# Altium schematic sheet [02] - nRF52.SchDoc("[02] - nRF52")
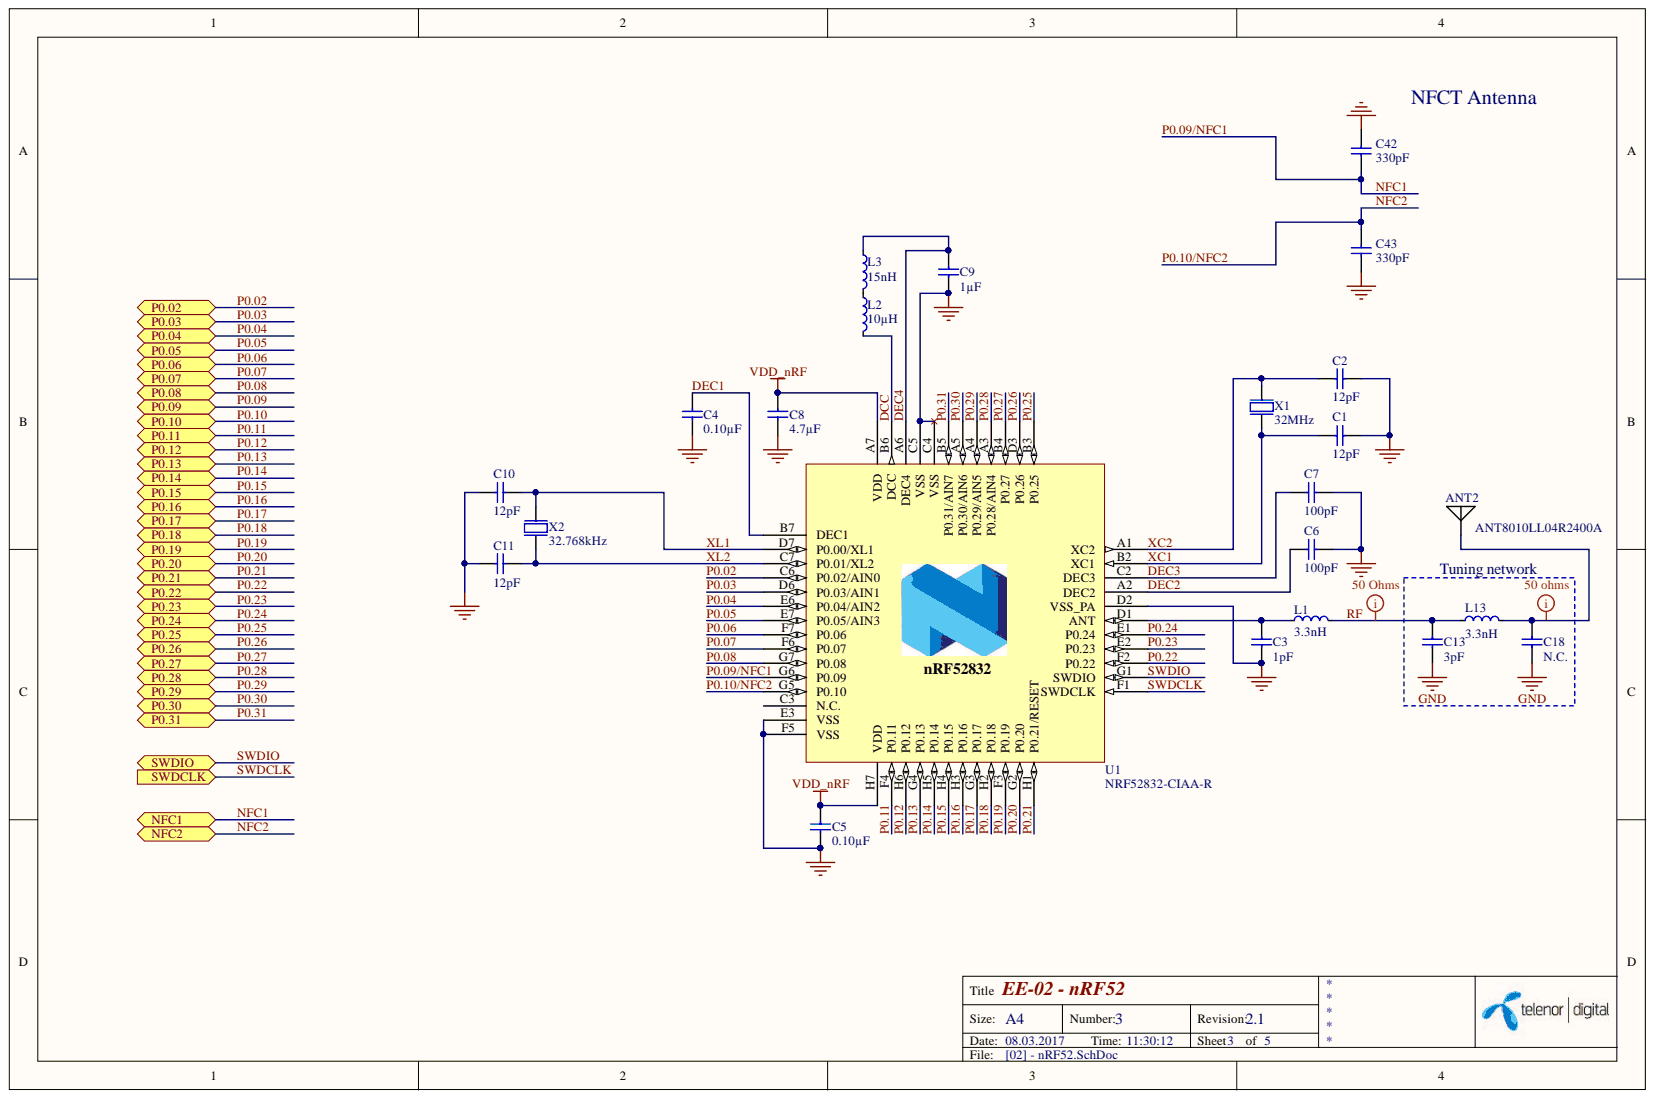
[diagram p0: [02] - nRF52.SchDoc]

## Parts
ANT2  ANT8010LL04R2400A  [YAGEO_24GHz]
C1  12pF  [CAPC0603X03L]
C2  12pF  [CAPC0603X03L]
C3  1pF  [CAPC0603X03L]
C4  0.10µF  [CAPC0603X03L]
C5  0.10µF  [CAPC0603X03L]
C6  100pF  [CAPC0603X03L]
C7  100pF  [CAPC0603X03L]
C8  4.7µF  [CAPC1608X06L]
C9  1µF  [CAPC1005X04L]
C10  12pF  [CAPC0603X03L]
C11  12pF  [CAPC0603X03L]
C13  3pF  [CAPC0603X03L]
C18  N.C.  [CAPC0603X03L]  (no BOM)
C42  330pF  [CAPC0603X03L]
C43  330pF  [CAPC0603X03L]
L1  3.3nH  [INDC0603X03L]
L2  10µH  [INDC1608X06L]
L3  15nH  [INDC1005X04L]
L13  3.3nH  [INDC0603X03L]
U1  NRF52832-CIAA-R  [BGA50C40P7X8_295X322X50]
X1  32MHz  [XTAL_ECS_2016]
X2  32.768kHz  [XTAL_1610]

## Ports
NFC1, NFC2, P0.02, P0.03, P0.04, P0.05, P0.06, P0.07, P0.08, P0.09, P0.10, P0.11, P0.12, P0.13, P0.14, P0.15, P0.16, P0.17, P0.18, P0.19, P0.20, P0.21, P0.22, P0.23, P0.24, P0.25, P0.26, P0.27, P0.28, P0.29, P0.30, P0.31, SWDCLK, SWDIO

## Nets
GND: C1.2 C2.2 C3.1 C4.2 C5.2 C6.2 C7.2 C8.2 C9.1 C10.2 C11.2 C13.1 C18.1 C42.2 C43.1 U1.C4(VSS) U1.C5(VSS) U1.D2(VSS_PA) U1.E3(VSS) U1.F5(VSS) X1.2 X1.4
VDD_nRF: C5.1 C8.1 U1.A7(VDD) U1.H7(VDD)
DCC: L2.2 U1.B6(DCC)
DEC1: C4.1 U1.B7(DEC1)
DEC2: C6.1 U1.A2(DEC2)
DEC3: C7.1 U1.C2(DEC3)
DEC4: C9.2 L3.1 U1.A6(DEC4)
NFC1: C42.1 U1.G6(P0.09) ; port NFC1
NFC2: C43.2 U1.G5(P0.10) ; port NFC2
P0.02: U1.C6(P0.02/AIN0) ; port P0.02
P0.03: U1.D6(P0.03/AIN1) ; port P0.03
P0.04: U1.E6(P0.04/AIN2) ; port P0.04
P0.05: U1.E7(P0.05/AIN3) ; port P0.05
P0.06: U1.F7(P0.06) ; port P0.06
P0.07: U1.F6(P0.07) ; port P0.07
P0.08: U1.G7(P0.08) ; port P0.08
P0.11: U1.F4(P0.11) ; port P0.11
P0.12: U1.H6(P0.12) ; port P0.12
P0.13: U1.G4(P0.13) ; port P0.13
P0.14: U1.H5(P0.14) ; port P0.14
P0.15: U1.H4(P0.15) ; port P0.15
P0.16: U1.H3(P0.16) ; port P0.16
P0.17: U1.G3(P0.17) ; port P0.17
P0.18: U1.H2(P0.18) ; port P0.18
P0.19: U1.F3(P0.19) ; port P0.19
P0.20: U1.G2(P0.20) ; port P0.20
P0.21: U1.H1(P0.21/RESET) ; port P0.21
P0.22: U1.F2(P0.22) ; port P0.22
P0.23: U1.E2(P0.23) ; port P0.23
P0.24: U1.E1(P0.24) ; port P0.24
P0.25: U1.B3(P0.25) ; port P0.25
P0.26: U1.D3(P0.26) ; port P0.26
P0.27: U1.B4(P0.27) ; port P0.27
P0.28: U1.A3(P0.28/AIN4) ; port P0.28
P0.29: U1.A4(P0.29/AIN5) ; port P0.29
P0.30: U1.A5(P0.30/AIN6) ; port P0.30
P0.31: U1.B5(P0.31/AIN7) ; port P0.31
RF: C13.2 L1.2 L13.1
SWDCLK: U1.F1(SWDCLK) ; port SWDCLK
SWDIO: U1.G1(SWDIO) ; port SWDIO
XC1: C1.1 U1.B2(XC1) X1.1
XC2: C2.1 U1.A1(XC2) X1.3
XL1: C10.1 U1.D7(P0.00/XL1) X2.1
XL2: C11.1 U1.C7(P0.01/XL2) X2.2
NetANT2_1: ANT2.1 C18.2 L13.2
NetC3_2: C3.2 L1.1 U1.D1(ANT)
NetL2_1: L2.1 L3.2
P0.09: ; port P0.09
P0.10: ; port P0.10

Not connected: U1 C3(N.C.)

## Library data of the parts
ANT2 = ANT8010LL04R2400A: CHIP ANTENNA 2.4GHZ; Manufacturer: Yageo; Power - Max: 1W; Number of Bands: 1; Gain: 5.46dBi; Frequency Range: 2.4GHz ~ 2.5GHz; Frequency Group: UHF (2 GHz ~ 3 GHz); VSWR: -; Termination: Solder; Supplier Part Number 1: 311-1550-6-ND; Supplier 1: Digi-Key; Stock 1: 771; RoHS: RoHS Compliant; Return Loss: -; Pricing 1: 1=1.4, 10=1.241, 25=1.1204, 100=0.9803, 250=0.85972, 500=0.76248, 750=0.66521, 1000=0.60297 (EUR); Mounting Type: Surface Mount; Manufacturer Part Number: ANT8010LL04R2400A; Ingress Protection: -; Height (Max): 0.039" (1.00mm); Frequency (Center/Band): 2.4GHz; Features: -; ComponentLink1URL: http://www.yageo.com/documents/recent/An_SMD_Bt_11bg_2450_8010_0.pdf; ComponentLink1Description: http://www.yageo.com/documents/recent/An_SMD_Bt_11bg_2450_8010_0.pdf; Component Kind: Standard; Category: RF/IF and RFID; Applications: Bluetooth, WLAN, Zigbee™; Antenna Type: Chip; Library Name: Skunkworks Library.IntLib
C1 C2 C10 C11 = GRM0335C1H120GA01D: CAP CER 12PF 50V NP0 0201; Manufacturer: Murata Electronics North America; Tolerance: ±2%; Temperature Coefficient: C0G, NP0; Voltage - Rated: 50V; Value: 12pF; Thickness (Max): 0.013" (0.33mm); Supplier Part Number 1: 490-11308-6-ND; Supplier 1: Digi-Key; Stock 1: 92426; Size / Dimension: 0.024" L x 0.012" W (0.60mm x 0.30mm); RoHS: RoHS Compliant; Ratings: -; Pricing 1: 1=0.1, 10=0.014, 50=0.0078, 100=0.0066, 250=0.0054, 500=0.00462, 1000=0.0036, 5000=0.003 (USD); Part Status: Active; Packaging: Digi-Reel®; Package / Case: 0201 (0603 Metric); Operating Temperature: -55°C ~ 125°C; Mounting Type: Surface Mount, MLCC; Manufacturer Part Number: GRM0335C1H120GA01D; Lead Style: -; Lead Spacing: -; Height - Seated (Max): -; Features: -; ComponentLink1URL: http://www.murata.com/~/media/webrenewal/support/library/catalog/products/capacitor/mlcc/c02e.pdf; ComponentLink1Description: http://www.murata.com/~/media/webrenewal/support/library/catalog/products/capacitor/mlcc/c02e.pdf; Component Kind: Standard; Category: Capacitors; Capacitance: 12pF; Applications: General Purpose; Library Name: Skunkworks Library.IntLib
C3 = GRM0335C1H1R0BA01D: CAP CER 1PF 50V NP0 0201; Manufacturer: Murata Electronics North America; Tolerance: ±0.1pF; Temperature Coefficient: C0G, NP0; Voltage - Rated: 50V; Value: 1pF; Thickness (Max): 0.013" (0.33mm); Supplier Part Number 1: 490-9660-6-ND; Supplier 1: Digi-Key; Stock 1: 205704; Size / Dimension: 0.024" L x 0.012" W (0.60mm x 0.30mm); RoHS: RoHS Compliant; Ratings: -; Pricing 1: 1=0.1, 10=0.014, 50=0.0078, 100=0.0066, 250=0.0054, 500=0.00462, 1000=0.0036, 5000=0.003 (USD); Part Status: Active; Packaging: Digi-Reel®; Package / Case: 0201 (0603 Metric); Operating Temperature: -55°C ~ 125°C; Mounting Type: Surface Mount, MLCC; Manufacturer Part Number: GRM0335C1H1R0BA01D; Lead Style: -; Lead Spacing: -; Height - Seated (Max): -; Features: -; ComponentLink1URL: http://www.murata.com/~/media/webrenewal/support/library/catalog/products/capacitor/mlcc/c02e.pdf; ComponentLink1Description: http://www.murata.com/~/media/webrenewal/support/library/catalog/products/capacitor/mlcc/c02e.pdf; Component Kind: Standard; Category: Capacitors; Capacitance: 1pF; Applications: General Purpose; Library Name: Skunkworks Library.IntLib
C4 C5 = GRM033R61C104KE84D: CAP CER 0.1UF 16V X5R 0201; Manufacturer: Murata Electronics North America; Tolerance: ±10%; Voltage - Rated: 16V; Value: 0.10µF; Thickness (Max): 0.013" (0.33mm); Temperature Coefficient: X5R; Supplier Part Number 1: 490-9985-6-ND; Supplier 1: Digi-Key; Stock 1: 0; Size / Dimension: 0.024" L x 0.012" W (0.60mm x 0.30mm); RoHS: RoHS Compliant; Ratings: -; Pricing 1: 1=0.1, 10=0.025, 50=0.0136, 100=0.0116, 250=0.00944, 500=0.00808, 1000=0.0063, 5000=0.00525 (USD); Part Status: Active; Packaging: Digi-Reel®; Package / Case: 0201 (0603 Metric); Operating Temperature: -55°C ~ 85°C; Mounting Type: Surface Mount, MLCC; Manufacturer Part Number: GRM033R61C104KE84D; Lead Style: -; Lead Spacing: -; Height - Seated (Max): -; Features: Derating Recommended; ComponentLink1URL: http://www.murata.com/~/media/webrenewal/support/library/catalog/products/capacitor/mlcc/c02e.pdf; ComponentLink1Description: http://www.murata.com/~/media/webrenewal/support/library/catalog/products/capacitor/mlcc/c02e.pdf; Component Kind: Standard; Category: Capacitors; Capacitance: 0.10µF; Applications: General Purpose; Library Name: Skunkworks Library.IntLib
C6 C7 = GRM0335C1H101GA01D: CAP CER 100PF 50V NP0 0201; Manufacturer: Murata Electronics North America; Tolerance: ±2%; Temperature Coefficient: C0G, NP0; Voltage - Rated: 50V; Value: 100pF; Thickness (Max): 0.013" (0.33mm); Supplier Part Number 1: 490-7751-6-ND; Supplier 1: Digi-Key; Stock 1: 457199; Size / Dimension: 0.024" L x 0.012" W (0.60mm x 0.30mm); RoHS: RoHS Compliant; Ratings: -; Pricing 1: 1=0.1, 10=0.014, 50=0.0078, 100=0.0066, 250=0.0054, 500=0.00462, 1000=0.0036, 5000=0.003 (USD); Part Status: Active; Packaging: Digi-Reel®; Package / Case: 0201 (0603 Metric); Operating Temperature: -55°C ~ 125°C; Mounting Type: Surface Mount, MLCC; Manufacturer Part Number: GRM0335C1H101GA01D; Lead Style: -; Lead Spacing: -; Height - Seated (Max): -; Features: -; ComponentLink1URL: http://www.murata.com/~/media/webrenewal/support/library/catalog/products/capacitor/mlcc/c02e.pdf; ComponentLink1Description: http://www.murata.com/~/media/webrenewal/support/library/catalog/products/capacitor/mlcc/c02e.pdf; Component Kind: Standard; Category: Capacitors; Capacitance: 100pF; Applications: General Purpose; Library Name: Skunkworks Library.IntLib
C8 = GRM188R60J475KE19D: CAP CER 4.7UF 6.3V X5R 0603; Manufacturer: Murata Electronics North America; Tolerance: ±10%; Voltage - Rated: 6.3V; Value: 4.7µF; Thickness (Max): 0.035" (0.90mm); Temperature Coefficient: X5R; Supplier Part Number 1: 490-3297-6-ND; Supplier 1: Digi-Key; Stock 1: 652092; Size / Dimension: 0.063" L x 0.031" W (1.60mm x 0.80mm); RoHS: RoHS Compliant; Ratings: -; Pricing 1: 1=0.12, 10=0.08, 50=0.046, 100=0.038, 250=0.032, 500=0.0268, 1000=0.022 (USD); Packaging: Digi-Reel®; Package / Case: 0603 (1608 Metric); Operating Temperature: -55°C ~ 85°C; Mounting Type: Surface Mount, MLCC; Manufacturer Part Number: GRM188R60J475KE19D; Lead Style: -; Lead Spacing: -; Height - Seated (Max): -; Features: -; ComponentLink1URL: http://www.murata.com/~/media/webrenewal/support/library/catalog/products/capacitor/mlcc/c02e.pdf; ComponentLink1Description: http://www.murata.com/~/media/webrenewal/support/library/catalog/products/capacitor/mlcc/c02e.pdf; Component Kind: Standard; Category: Capacitors; Capacitance: 4.7µF; Applications: General Purpose; Library Name: Skunkworks Library.IntLib
C9 = GRM155R70J105KA12D: CAP CER 1UF 6.3V X7R 0402; Manufacturer: Murata Electronics North America; Tolerance: ±10%; Voltage - Rated: 6.3V; Value: 1µF; Thickness (Max): 0.022" (0.55mm); Temperature Coefficient: X7R; Supplier Part Number 1: 490-10024-6-ND; Supplier 1: Digi-Key; Stock 1: 3321; Size / Dimension: 0.039" L x 0.020" W (1.00mm x 0.50mm); RoHS: RoHS Compliant; Ratings: -; Pricing 1: 1=0.1, 10=0.072, 50=0.039, 100=0.033, 250=0.027, 500=0.0231, 1000=0.018, 5000=0.015 (USD); Part Status: Active; Packaging: Digi-Reel®; Package / Case: 0402 (1005 Metric); Operating Temperature: -55°C ~ 125°C; Mounting Type: Surface Mount, MLCC; Manufacturer Part Number: GRM155R70J105KA12D; Lead Style: -; Lead Spacing: -; Height - Seated (Max): -; Features: Derating Recommended; ComponentLink1URL: http://www.murata.com/~/media/webrenewal/support/library/catalog/products/capacitor/mlcc/c02e.pdf; ComponentLink1Description: http://www.murata.com/~/media/webrenewal/support/library/catalog/products/capacitor/mlcc/c02e.pdf; Component Kind: Standard; Category: Capacitors; Capacitance: 1µF; Applications: General Purpose; Library Name: Skunkworks Library.IntLib
C13 = 250R05L3R0BV4T: CAP CER 3PF 25V NP0 0201; Manufacturer: Johanson Technology Inc.; Tolerance: ±0.1pF; Temperature Coefficient: C0G, NP0; Voltage - Rated: 25V; Value: 3pF; Thickness (Max): 0.013" (0.33mm); Supplier Part Number 1: 712-1191-6-ND; Supplier 1: Digi-Key; Stock 1: 107635; Size / Dimension: 0.024" L x 0.012" W (0.60mm x 0.30mm); RoHS: RoHS Compliant; Ratings: -; Pricing 1: 1=0.23, 10=0.16, 25=0.1172, 50=0.0958, 100=0.0809, 250=0.06596, 500=0.05532, 1000=0.04894, 5000=0.04256 (USD); Part Status: Active; Packaging: Digi-Reel®; Package / Case: 0201 (0603 Metric); Operating Temperature: -55°C ~ 125°C; Mounting Type: Surface Mount, MLCC; Manufacturer Part Number: 250R05L3R0BV4T; Lead Style: -; Lead Spacing: -; Height - Seated (Max): -; Features: High Q, Low Loss; ComponentLink1URL: http://www.johansontechnology.com/downloads/JTI-CAT-MLCC-HighQ.pdf; ComponentLink1Description: http://www.johansontechnology.com/downloads/JTI-CAT-MLCC-HighQ.pdf; Component Kind: Standard; Category: Capacitors; Capacitance: 3pF; Applications: RF, Microwave, High Frequency; Library Name: Skunkworks Library.IntLib
C18 = CAP_0201-NC: Capacitor, 0201, N.C.; Part Number: C0201-NC; Artikkelnr Simpro: N.A.; Value: N.C.; Published: 2016-11-04; Pin Count: 2; LatestRevisionDate: 2016-11-04; Footprint Doc: 0201; Artikkelnr Noca: N.A.; Publisher: Telenor Digital; Library Name: Skunkworks Library.IntLib
C42 C43 = GRM033R71C331KA01D: CAP CER 330PF 16V X7R 0201; Manufacturer: Murata Electronics North America; Tolerance: ±10%; Voltage - Rated: 16V; Value: 330pF; Thickness (Max): 0.013" (0.33mm); Temperature Coefficient: X7R; Supplier Part Number 1: 490-11359-6-ND; Supplier 1: Digi-Key; Stock 1: 85950; Size / Dimension: 0.024" L x 0.012" W (0.60mm x 0.30mm); RoHS: RoHS Compliant; Ratings: -; Pricing 1: 1=0.1, 10=0.018, 50=0.0098, 100=0.0083, 250=0.00676, 500=0.00578, 1000=0.0045, 5000=0.00375 (USD); Part Status: Active; Packaging: Digi-Reel®; Package / Case: 0201 (0603 Metric); Operating Temperature: -55°C ~ 125°C; Mounting Type: Surface Mount, MLCC; Manufacturer Part Number: GRM033R71C331KA01D; Lead Style: -; Lead Spacing: -; Height - Seated (Max): -; Features: -; ComponentLink1URL: http://www.murata.com/~/media/webrenewal/support/library/catalog/products/capacitor/mlcc/c02e.pdf; ComponentLink1Description: http://www.murata.com/~/media/webrenewal/support/library/catalog/products/capacitor/mlcc/c02e.pdf; Component Kind: Standard; Category: Capacitors; Capacitance: 330pF; Applications: General Purpose; Library Name: Skunkworks Library.IntLib
L1 L13 = LQP03TN3N3B02D: FIXED IND 3.3NH 450MA 250 MOHM; Manufacturer: Murata Electronics North America; Type: Thin Film; Tolerance: ±0.1nH; Shielding: Unshielded; Q @ Freq: 14 @ 500MHz; Material - Core: Non-Magnetic; Frequency - Test: 500MHz; Frequency - Self Resonant: 8GHz; DC Resistance (DCR): 250 mOhm Max; Value: 3.3nH; Supplier Part Number 1: 490-12988-6-ND; Supplier 1: Digi-Key; Stock 1: 28077; Size / Dimension: 0.024" L x 0.012" W (0.60mm x 0.30mm); RoHS: RoHS Compliant; Ratings: -; Pricing 1: 1=0.1, 10=0.05, 25=0.0452, 50=0.0406, 100=0.0351, 250=0.03152, 500=0.02926, 750=0.027, 1000=0.02565 (USD); Part Status: Active; Packaging: Digi-Reel®; Package / Case: 0201 (0603 Metric); Operating Temperature: -55°C ~ 125°C; Mounting Type: Surface Mount; Manufacturer Part Number: LQP03TN3N3B02D; Inductance: 3.3nH; Height - Seated (Max): 0.013" (0.33mm); Current Rating: 450mA; Current - Saturation: -; ComponentLink1URL: http://search.murata.co.jp/Ceramy/image/img/P02/JELF243C-0015.pdf; ComponentLink1Description: http://search.murata.co.jp/Ceramy/image/img/P02/JELF243C-0015.pdf; Component Kind: Standard; Category: Inductors, Coils, Chokes; Library Name: Skunkworks Library.IntLib
L2 = LBMF1608T100K: FIXED IND 10UH 80MA 360 MOHM SMD; Manufacturer: Taiyo Yuden; Type: Wirewound; Tolerance: ±10%; Shielding: Unshielded; Frequency - Test: 2.52MHz; Frequency - Self Resonant: 32MHz; Value: 10µH; Supplier Part Number 1: 587-1714-6-ND; Supplier 1: Digi-Key; Stock 1: 39014; Size / Dimension: 0.063" L x 0.031" W (1.60mm x 0.80mm); RoHS: RoHS Compliant; Ratings: -; Q @ Freq: -; Pricing 1: 1=0.25, 10=0.225, 25=0.2024, 50=0.1756, 100=0.1575, 250=0.14624, 500=0.135, 1000=0.117 (USD); Packaging: Digi-Reel®; Package / Case: 0603 (1608 Metric); Operating Temperature: -40°C ~ 105°C; Mounting Type: Surface Mount; Material - Core: -; Manufacturer Part Number: LBMF1608T100K; Inductance: 10µH; Height - Seated (Max): 0.039" (1.00mm); DC Resistance (DCR): 360 mOhm; Current Rating: 80mA; Current - Saturation: -; ComponentLink1URL: http://ds.yuden.co.jp/TYCOMPAS/ut/detail.do?productNo=LBMF1608T100K&fileName=LBMF1608T100K_SS&mode=specSheetDownload; ComponentLink1Description: http://ds.yuden.co.jp/TYCOMPAS/ut/detail.do?productNo=LBMF1608T100K&fileName=LBMF1608T100K_SS&mode=specSheetDownload; Component Kind: Standard; Category: Inductors, Coils, Chokes; Library Name: Skunkworks Library.IntLib
L3 = HK100515NJ-T: FIXED IND 15NH 320MA 460 MOHM; Manufacturer: Taiyo Yuden; Type: Multilayer; Tolerance: ±5%; Shielding: Unshielded; Q @ Freq: 8 @ 100MHz; Material - Core: Ferrite; Frequency - Test: 100MHz; Frequency - Self Resonant: 2.3GHz; DC Resistance (DCR): 460 mOhm Max; Value: 15nH; Supplier Part Number 1: 587-1521-6-ND; Supplier 1: Digi-Key; Stock 1: 163730; Size / Dimension: 0.039" L x 0.020" W (1.00mm x 0.50mm); RoHS: RoHS Compliant; Ratings: -; Pricing 1: 1=0.1, 10=0.06, 25=0.054, 50=0.0468, 100=0.042, 250=0.039, 500=0.036, 1000=0.0312 (USD); Packaging: Digi-Reel®; Package / Case: 0402 (1005 Metric); Operating Temperature: -55°C ~ 125°C; Mounting Type: Surface Mount; Manufacturer Part Number: HK100515NJ-T; Inductance: 15nH; Height - Seated (Max): 0.022" (0.55mm); Current Rating: 320mA; Current - Saturation: -; ComponentLink1URL: http://ds.yuden.co.jp/TYCOMPAS/ut/detail.do?productNo=HK100515NJ-T&fileName=HK100515NJ-T_SS&mode=specSheetDownload; ComponentLink1Description: http://ds.yuden.co.jp/TYCOMPAS/ut/detail.do?productNo=HK100515NJ-T&fileName=HK100515NJ-T_SS&mode=specSheetDownload; Component Kind: Standard; Category: Inductors, Coils, Chokes; Library Name: Skunkworks Library.IntLib
U1 = NRF52832-CIAA-R: nRF52832-CIAA - IC RF TXRX+MCU BLUETOOTH 50WLCSP; Manufacturer: Nordic Semiconductor ASA; Value: NRF52832-CIAA-R; Type: TxRx + MCU; Supplier Part Number 1: 1490-1056-6-ND; Supplier 1: Digi-Key; Stock 1: 0; RoHS: RoHS Compliant; Pricing 1: 1=5.91, 10=5.276, 25=4.7488, 100=4.3265, 250=3.90444, 500=3.50344, 1000=2.9547 (USD); Part Status: Active; Packaging: Digi-Reel®; Manufacturer Part Number: NRF52832-CIAA-R; ComponentLink1URL: http://infocenter.nordicsemi.com/pdf/nRF52832_PB_v1.4.pdf; ComponentLink1Description: http://infocenter.nordicsemi.com/pdf/nRF52832_PB_v1.4.pdf; Component Kind: Standard; Category: RF/IF and RFID; Library Name: Skunkworks Library.IntLib
X1 = ECS-320-8-37CKM: CRYSTAL 32.0000MHZ 8PF SMD; Manufacturer: ECS Inc.; Operating Mode: Fundamental; Frequency Tolerance: ±10ppm; ESR (Equivalent Series Resistance): 60 Ohm; Value: 32MHz; Type: MHz Crystal; Supplier Part Number 1: XC1547DKR-ND; Supplier 1: Digi-Key; Stock 1: 8917; Size / Dimension: 0.079" L x 0.063" W (2.00mm x 1.60mm); RoHS: RoHS Compliant; Ratings: -; Pricing 1: 1=1.09, 10=0.968, 50=0.904, 100=0.8, 500=0.756 (USD); Part Status: Active; Packaging: Digi-Reel®; Package / Case: 4-SMD, No Lead (DFN, LCC); Operating Temperature: -20°C ~ 70°C; Mounting Type: Surface Mount; Manufacturer Part Number: ECS-320-8-37CKM; Load Capacitance: 8pF; Height: 0.020" (0.50mm); Frequency Stability: ±10ppm; Frequency: 32MHz; ComponentLink1URL: http://www.ecsxtal.com/store/pdf/ecx-1637.pdf; ComponentLink1Description: http://www.ecsxtal.com/store/pdf/ecx-1637.pdf; Component Kind: Standard; Category: Crystals, Oscillators, Resonators; Library Name: Skunkworks Library.IntLib
X2 = 9HT12-32.768KBZC-T: CRYSTAL 32.7680KHZ 9PF SMD; Manufacturer: TXC CORPORATION; Operating Mode: Fundamental; Frequency Tolerance: ±50ppm; ESR (Equivalent Series Resistance): 90 kOhm; Value: 32.768kHz; Type: kHz Crystal (Tuning Fork); Supplier Part Number 1: 887-2621-6-ND; Supplier 1: Digi-Key; Stock 1: 4978; Size / Dimension: 0.063" L x 0.039" W (1.60mm x 1.00mm); RoHS: RoHS Compliant; Ratings: -; Pricing 1: 1=1.14, 10=1.088, 50=1.0626, 100=0.9108, 500=0.8855, 1000=0.74635, 2500=0.7084 (USD); Part Status: Active; Packaging: Digi-Reel®; Package / Case: 2-SMD, No Lead (DFN, LCC); Operating Temperature: -40°C ~ 85°C; Mounting Type: Surface Mount; Manufacturer Part Number: 9HT12-32.768KBZC-T; Load Capacitance: 9pF; Height: 0.020" (0.50mm); Frequency Stability: -; Frequency: 32.768kHz; ComponentLink1URL: http://www.txccrystal.com/images/pdf/9ht12.pdf; ComponentLink1Description: http://www.txccrystal.com/images/pdf/9ht12.pdf; Component Kind: Standard; Category: Crystals, Oscillators, Resonators; Library Name: Skunkworks Library.IntLib
